# Altium schematic sheet pico2hat_halow.SchDoc(pico2hat_halow)
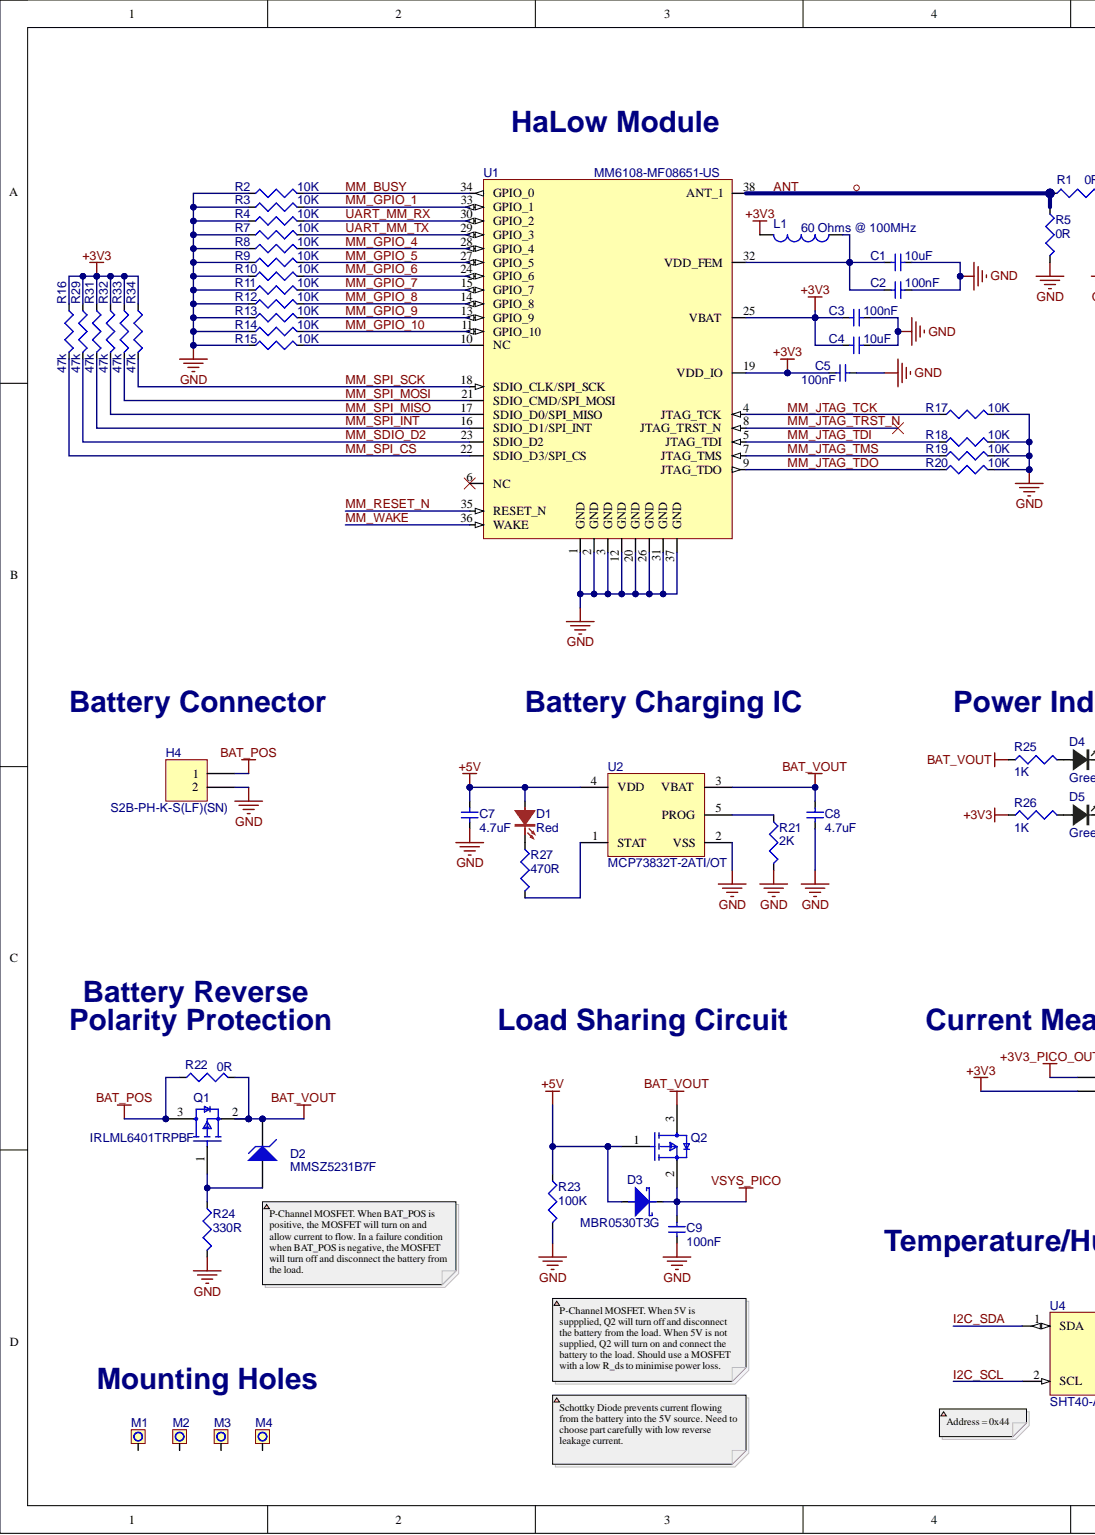
[diagram p0: pico2hat_halow.SchDoc, left]
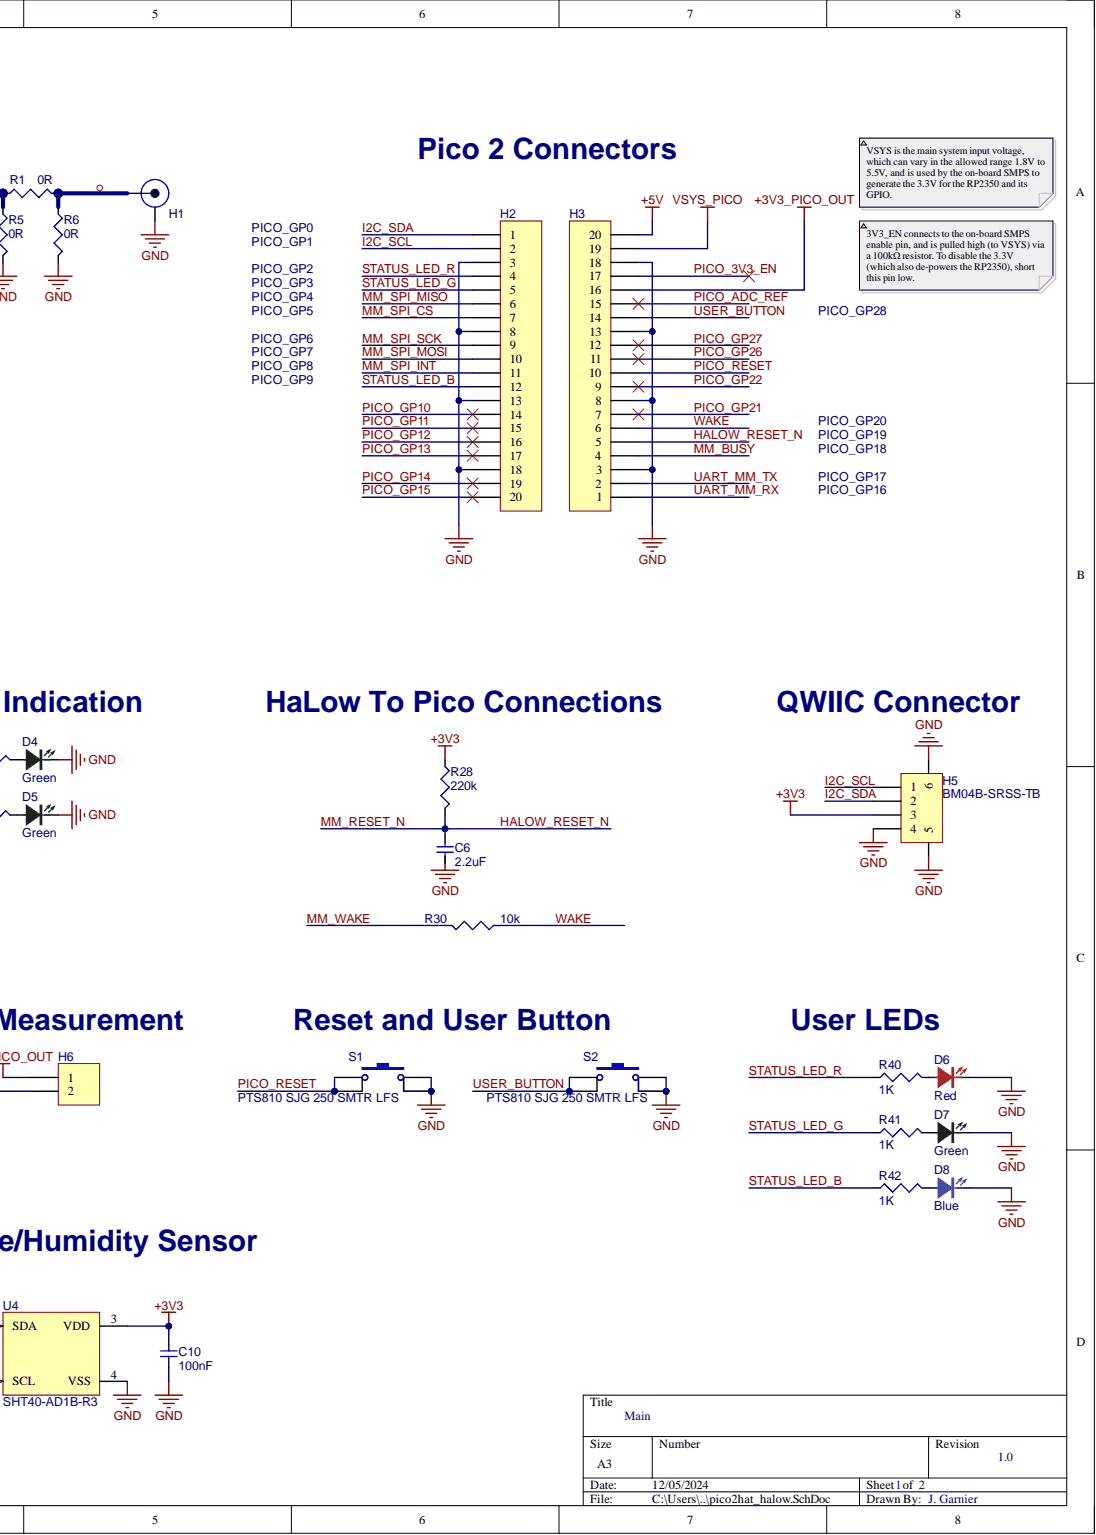
[diagram p1: pico2hat_halow.SchDoc, right]

## Parts
C1  value 10uF  [0402_cap]  (hidden comment: CL05A106MP8NUB8)
C2  value 100nF  [0402_cap]  (hidden comment: C1005X7R1H104K050BE)
C3  value 100nF  [0402_cap]  (hidden comment: C1005X7R1H104K050BE)
C4  value 10uF  [0402_cap]  (hidden comment: CL05A106MP8NUB8)
C5  value 100nF  [0402_cap]  (hidden comment: C1005X7R1H104K050BE)
C6  value 2.2uF  [CAPC1005X06N]  (hidden comment: CAP)
C7  value 4.7uF  [0402_cap]  (hidden comment: C1005X5R0J475K050BC)
C8  value 4.7uF  [0402_cap]  (hidden comment: C1005X5R0J475K050BC)
C9  value 100nF  [0402_cap]  (hidden comment: C1005X7R1H104K050BE)
C10  value 100nF  [0402_cap]  (hidden comment: C1005X7R1H104K050BE)
D1  value Red  [LED_0805_RED]  (hidden comment: LTST-C170KRKT)
D2  MMSZ5231B7F  [SOD-123FL]
D3  MBR0530T3G  [SOD-123FL]
D4  value Green  [LED_0402_GREEN]  (hidden comment: XZVGR68W-3)
D5  value Green  [LED_0402_GREEN]  (hidden comment: XZVGR68W-3)
D6  value Red  [LED_0805_RED]  (hidden comment: LTST-C170KRKT)
D7  value Green  [LED_0805_GREEN]  (hidden comment: LTST-C170KGKT)
D8  value Blue  [LED_0805_BLUE]  (hidden comment: LTST-C170TBKT)
H1  142-0761-861  [SMA_EMERSON_142_0761_861]  (value not drawn)
H2  1x20 Female Header  [HDR1X20F]  (value not drawn)
H3  1x20 Female Header  [HDR1X20F]  (value not drawn)
H4  S2B-PH-K-S(LF)(SN)  [JST-S2B-PH-K-S_V]
H5  BM04B-SRSS-TB  [FP-BM04B-SRSS-TBT_LF_SN-MFG]
H6  2011-1X02G00SB-1  [HDR1X2]  (value not drawn)
L1  value 60 Ohms @ 100MHz  [0402_ind]  (hidden comment: BLM15PX600SN1D)
M1  PAD0009  [MH_300_275_NPTH]  (value not drawn)
M2  PAD0009  [MH_300_275_NPTH]  (value not drawn)
M3  PAD0009  [MH_300_275_NPTH]  (value not drawn)
M4  PAD0009  [MH_300_275_NPTH]  (value not drawn)
Q1  IRLML6401TRPBF  [FP-SOT23-IPC_A]
Q2  NTS4101PT1G  [FP-419-04-IPC_A]  (value not drawn)
R1  value 0R  [0402_res]  (hidden comment: RC0402JR-070RL)
R2  value 10K  [RESC1005X04N]  (hidden comment: ERJ-2RKF1002X)
R3  value 10K  [RESC1005X04N]  (hidden comment: ERJ-2RKF1002X)
R4  value 10K  [RESC1005X04N]  (hidden comment: ERJ-2RKF1002X)
R5  value 0R  [0402_res]  (hidden comment: RC0402JR-070RL)
R6  value 0R  [0402_res]  (hidden comment: RC0402JR-070RL)
R7  value 10K  [RESC1005X04N]  (hidden comment: ERJ-2RKF1002X)
R8  value 10K  [RESC1005X04N]  (hidden comment: ERJ-2RKF1002X)
R9  value 10K  [RESC1005X04N]  (hidden comment: ERJ-2RKF1002X)
R10  value 10K  [RESC1005X04N]  (hidden comment: ERJ-2RKF1002X)
R11  value 10K  [RESC1005X04N]  (hidden comment: ERJ-2RKF1002X)
R12  value 10K  [RESC1005X04N]  (hidden comment: ERJ-2RKF1002X)
R13  value 10K  [RESC1005X04N]  (hidden comment: ERJ-2RKF1002X)
R14  value 10K  [RESC1005X04N]  (hidden comment: ERJ-2RKF1002X)
R15  value 10K  [RESC1005X04N]  (hidden comment: ERJ-2RKF1002X)
R16  value 47k  [RESC1005X04N]  (hidden comment: MCR01MZPF4702)
R17  value 10K  [RESC1005X04N]  (hidden comment: ERJ-2RKF1002X)
R18  value 10K  [RESC1005X04N]  (hidden comment: ERJ-2RKF1002X)
R19  value 10K  [RESC1005X04N]  (hidden comment: ERJ-2RKF1002X)
R20  value 10K  [RESC1005X04N]  (hidden comment: ERJ-2RKF1002X)
R21  value 2K  [0402_res]  (hidden comment: RC0402FR-072KL)
R22  value 0R  [RESC1608X06N]  (hidden comment: RC0603JR-070RL)
R23  value 100K  [0402_res]  (hidden comment: RC0402FR-07100KL)
R24  value 330R  [RESC1005X04N]  (hidden comment: RC0402FR-07330RL)
R25  value 1K  [RESC1005X04N]  (hidden comment: ERJ-2RKF1001X)
R26  value 1K  [RESC1005X04N]  (hidden comment: ERJ-2RKF1001X)
R27  value 470R  [0402_res]  (hidden comment: RC0402FR-07470RL)
R28  value 220k  [RESC1005X04N]  (hidden comment: RES)
R29  value 47k  [RESC1005X04N]  (hidden comment: MCR01MZPF4702)
R30  value 10k  [RESC1608X06N]  (hidden comment: RES)
R31  value 47k  [RESC1005X04N]  (hidden comment: MCR01MZPF4702)
R32  value 47k  [RESC1005X04N]  (hidden comment: MCR01MZPF4702)
R33  value 47k  [RESC1005X04N]  (hidden comment: MCR01MZPF4702)
R34  value 47k  [RESC1005X04N]  (hidden comment: MCR01MZPF4702)
R40  value 1K  [RESC1005X04N]  (hidden comment: ERJ-2RKF1001X)
R41  value 1K  [RESC1005X04N]  (hidden comment: ERJ-2RKF1001X)
R42  value 1K  [RESC1005X04N]  (hidden comment: ERJ-2RKF1001X)
S1  PTS810 SJG 250 SMTR LFS  [SW_PTS810]
S2  PTS810 SJG 250 SMTR LFS  [SW_PTS810]
U1  MM6108-MF08651-US  [MF04]
U2  MCP73832T-2ATI/OT  [SOT-23-OT5_N]
U4  SHT40-AD1B-R3  [DFN140P150X59-4N]

## Nets
+3V3: C3.1 C4.1 C5.1 C10.2 H5.3 H6.2 L1.2 R16.1 R26.1 R28.1 R29.1 R31.1 R32.1 R33.1 R34.1 U1.19(VDD_IO) U1.25(VBAT) U4.3(VDD)
+3V3_PICO_OUT: H3.16 H6.1
+5V: C7.1 D1.A D3.A H3.20 Q2.1 R23.1 U2.4(VDD)
BAT_POS: H4.1 Q1.3 R22.1
BAT_VOUT: C8.1 D2.K Q1.2 Q2.3 R22.2 R25.1 U2.3(VBAT)
GND: C1.2 C2.2 C3.2 C4.2 C5.2 C6.2 C7.2 C8.2 C9.1 C10.1 D4.K D5.K D6.K D7.K D8.K H1.2 H2.3 H2.8 H2.13 H2.18 H3.3 H3.8 H3.13 H3.18 H4.2 H5.4 H5.5 H5.6 R2.1 R3.1 R4.1 R5.2 R6.2 R7.1 R8.1 R9.1 R10.1 R11.1 R12.1 R13.1 R14.1 R15.1 R17.2 R18.2 R19.2 R20.2 R21.2 R23.2 R24.2 S1.3 S1.4 S2.3 S2.4 U1.1(GND) U1.2(GND) U1.3(GND) U1.12(GND) U1.20(GND) U1.26(GND) U1.31(GND) U1.37(GND) U2.2(VSS) U4.4(VSS)
VSYS_PICO: C9.2 D3.K H3.19 Q2.2
ANT: R1.1 R5.1 U1.38(ANT_1)
HALOW_RESET_N: C6.1 H3.5 R28.2 U1.35(RESET_N)
I2C_SCL: H2.2 H5.1 U4.2(SCL)
I2C_SDA: H2.1 H5.2 U4.1(SDA)
MM_BUSY: H3.4 R2.2 U1.34(GPIO_0)
MM_GPIO_1: R3.2 U1.33(GPIO_1)
MM_GPIO_4: R8.2 U1.28(GPIO_4)
MM_GPIO_5: R9.2 U1.27(GPIO_5)
MM_GPIO_6: R10.2 U1.24(GPIO_6)
MM_GPIO_7: R11.2 U1.15(GPIO_7)
MM_GPIO_8: R12.2 U1.14(GPIO_8)
MM_GPIO_9: R13.2 U1.13(GPIO_9)
MM_GPIO_10: R14.2 U1.11(GPIO_10)
MM_JTAG_TCK: R17.1 U1.4(JTAG_TCK)
MM_JTAG_TDI: R18.1 U1.5(JTAG_TDI)
MM_JTAG_TDO: R20.1 U1.9(JTAG_TDO)
MM_JTAG_TMS: R19.1 U1.7(JTAG_TMS)
MM_JTAG_TRST_N: U1.8(JTAG_TRST_N)
MM_SDIO_D2: R29.2 U1.23(SDIO_D2)
MM_SPI_CS: H2.7 R16.2 U1.22(SDIO_D3/SPI_CS)
MM_SPI_INT: H2.11 R31.2 U1.16(SDIO_D1/SPI_INT)
MM_SPI_MISO: H2.6 R32.2 U1.17(SDIO_D0/SPI_MISO)
MM_SPI_MOSI: H2.10 R33.2 U1.21(SDIO_CMD/SPI_MOSI)
MM_SPI_SCK: H2.9 R34.2 U1.18(SDIO_CLK/SPI_SCK)
MM_WAKE: R30.1 U1.36(WAKE)
PICO_3V3_EN: H3.17
PICO_ADC_REF: H3.15
PICO_GP10: H2.14
PICO_GP11: H2.15
PICO_GP12: H2.16
PICO_GP13: H2.17
PICO_GP14: H2.19
PICO_GP15: H2.20
PICO_GP21: H3.7
PICO_GP22: H3.9
PICO_GP26: H3.11
PICO_GP27: H3.12
PICO_RESET: H3.10 S1.1 S1.2
STATUS_LED_B: H2.12 R42.1
STATUS_LED_G: H2.5 R41.1
STATUS_LED_R: H2.4 R40.1
UART_MM_RX: H3.1 R4.2 U1.30(GPIO_2)
UART_MM_TX: H3.2 R7.2 U1.29(GPIO_3)
USER_BUTTON: H3.14 S2.1 S2.2
WAKE: H3.6 R30.2
NetC1_1: C1.1 C2.1 L1.1 U1.32(VDD_FEM)
NetD1_K: D1.K R27.1
NetD2_A: D2.A Q1.1 R24.1
NetD4_A: D4.A R25.2
NetD5_A: D5.A R26.2
NetD6_A: D6.A R40.2
NetD7_A: D7.A R41.2
NetD8_A: D8.A R42.2
NetH1_1: H1.1 R1.2 R6.1
NetR15_2: R15.2 U1.10(NC)
NetR21_1: R21.1 U2.5(PROG)
NetR27_2: R27.2 U2.1(STAT)

Not connected: M1 1; M2 1; M3 1; M4 1; U1 6(NC)

## Library data of the parts
C1 C4 = CAP0046-13: CAP CER 10UF 10V 20% X5R 0402; Generic Allowed: Yes; Subtype: Ceramic; ClassName: CHIP_MICRO; Component Kind: Standard; Package: 0402; Tolerance: 20%; Voltage: 10V; Library Name: Morse Micro Pty Limited
C2 C3 C5 C9 C10 = CAP0068-11: CAP CER 100NF 50V ±10% X7R 0402; Generic Allowed: Yes; Subtype: Ceramic; ClassName: CHIP_MICRO; Component Kind: Standard; Component Rating: X7R; Package: 0402; Tolerance: 10%; Voltage: 50V; Library Name: Morse Micro Pty Limited
C6 = CAP0086: CAP CER 2.2UF 6.3V 20% X5R 0402; Manufacturer 1: Taiyo Yuden; Manufacturer Part Number 1: JMK105BJ225MV-F; Database Table Name: Capacitor; Generic Allowed: Yes; Subtype: Ceramic; ClassName: CHIP_MICRO; Component Kind: Standard; Component Rating: X5R; Item_Number: CAP0086; Library Name: Altium_Library.DbLib; Package: 0402; Supplier 1: Digi-Key; Supplier Part Number 1: 587-1453-2-ND; Tolerance: 20%; Voltage: 6.3V
C7 C8 = CAP0075-12: CAP CER 4.7UF 6.3V ±10% X5R 0402; Generic Allowed: Yes; Subtype: Ceramic; ClassName: CHIP_MICRO; Component Kind: Standard; Component Rating: X5R; Package: 0402; Tolerance: 10%; Voltage: 6.3V; Library Name: Morse Micro Pty Limited
D1 D6 = DIO0016-2: LED, Red Clear,2.0V, SMD, 0805, RoHS; Generic Allowed: Yes; ClassName: CHIP_OTHER; Component Kind: Standard; Component Rating: Clear, 2.0V; Package: SMD-0805; Library Name: Morse Micro Pty Limited
D2 = DIO0072-1: DIODE ZENER 5.1V 500MW SOD-123; Component Kind: Standard; Library Name: Morse Micro Pty Limited
D3 = DIO0044-2: DIODE SCHOTTKY 30V 500MA SOD123; Generic Allowed: Yes; ClassName: COMP_OTHER; Component Kind: Standard; Current: 500mA; Package: SOD-123; Value: MBR0530T3G; Voltage: 30V; Library Name: Morse Micro Pty Limited
D4 D5 = DIO0053-3: LED GREEN 0402 SMD; Generic Allowed: Yes; Voltage: 2.0V; ClassName: CHIP_OTHER; Component Kind: Standard; Package: 0402; Library Name: Morse Micro Pty Limited
D7 = DIO0015-2: LED, Green Clear,2.0V, SMD, 0805, RoHS; Generic Allowed: Yes; ClassName: CHIP_OTHER; Component Kind: Standard; Component Rating: Clear, 2.0V; Package: SMD-0805; Library Name: Morse Micro Pty Limited
D8 = DIO0018-2: LED, Blue Clear,3.3V, SMD, 0805, RoHS; Generic Allowed: Yes; ClassName: CHIP_OTHER; Component Kind: Standard; Component Rating: Clear, 3.3V; Package: SMD-0805; Library Name: Morse Micro Pty Limited
H1 = JCK0001-2: Conn, SMA Jack 50 Ohm PCB Edge Mount Gold, RoHS; Generic Allowed: No; ClassName: COMP_OTHER; Component Kind: Standard; Package: Edge Mnt; Library Name: Morse Micro Pty Limited
H2 H3 = HDR0128-1: Component Kind: Standard; Description: 1x20 Female Header; Library Name: Morse Micro Pty Limited
H4 = HDR0129-2: Header for PCB; pitch 2.00mm; 2-contacts; THT; male; side entry; 100V/2A; Component Kind: Standard; Library Name: Morse Micro Pty Limited
H5 = HDR0131-2: Board Connector, 4 Contact(s), 1 Row(s), Male, Straight, Surface Mount Terminal; Component Kind: Standard; Library Name: Morse Micro Pty Limited
H6 = HDR0006-2: Conn Straight Header 2x1 0.100" 2 POS; Generic Allowed: Yes; ClassName: COMP_OTHER; Component Kind: Standard; Package: THRU-Hole; Pins: 2; Library Name: Morse Micro Pty Limited
L1 = IND0062-6: BEAD 60OHMS 2500MA 32 MOHM; Current: 2.5A; DC Resistance: 32mOhm; Generic Allowed: Yes; Value: 60 Ohms @ 100MHz; ClassName: CHIP_MICRO; Component Kind: Standard; Package: 0402; Resistance: 32mOhm; Library Name: Morse Micro Pty Limited
M1-M4 = PAD0009-2: MH NPTH 3.00mm DIA 2.75mm HOLE; Shape: Round; ClassName: PCB_PATTERN; Component Kind: Standard; Library Name: Morse Micro Pty Limited
Q1 = TSR0020-1: Small Signal Field-Effect Transistor; Additional Feature: HIGH RELIABILITY; Avalanche Energy Rating (Eas): 33mJ; Drain Current-Max (Abs) (ID): 4.3A; Drain-source On Resistance-Max: 50mR; DS Breakdown Voltage-Min: 12V; FET Technology: METAL-OXIDE SEMICONDUCTOR; Number of Elements: 1; Operating Mode: ENHANCEMENT MODE; Package Body Material: Plastic; Polarity/Channel Type: P-CHANNEL; Power Dissipation-Max (Abs): 1.3W; Pulsed Drain Current-Max (IDM): 34A; Qualification Status: Not Qualified; Time @ Peak Reflow Temperature-Max (s): 30s; Transistor Application: SWITCHING; Transistor Element Material: SILICON; Component Kind: Standard; Drain Current-Max (ID): 4.3A; JESD-30 Code: R-PDSO-G3; JESD-609 Code: e3; Max Operating Temperature: 150°C; Moisture Sensitivity Level: 1; Package Shape: Rectangular; Package Style: SMALL OUTLINEMeter; Peak Reflow Temperature (Cel): 260°C; Pins: 3; Reach SVHC Compliant: Yes; RoHS Compliant: Yes; Surface Mount: Yes; Terminal Finish: Tin; Terminal Form: Gull Wing; Terminal Position: DUAL; Library Name: Morse Micro Pty Limited
Q2 = TSR0021-1: Small Signal Field-Effect Transistor, 1.37A I(D), 20V, 1-Element, P-Channel, Silicon, Metal-oxide Semiconductor FET; Drain-source On Resistance-Max: 120mR; Feedback Cap-Max (Crss): 85pF; Number of Elements: 1; Operating Mode: ENHANCEMENT MODE; Package Body Material: Plastic; Power Dissipation-Max (Abs): 329mW; Qualification Status: Not Qualified; Time @ Peak Reflow Temperature-Max (s): 40s; Transistor Application: SWITCHING; Component Kind: Standard; Drain Current-Max (Abs) (ID): 1.37A; Drain Current-Max (ID): 1.37A; DS Breakdown Voltage-Min: 20V; FET Technology: METAL-OXIDE SEMICONDUCTOR; JESD-30 Code: R-PDSO-G3; JESD-609 Code: e3; Max Operating Temperature: 150°C; Moisture Sensitivity Level: 1; Package Shape: Rectangular; Package Style: SMALL OUTLINEMeter; Peak Reflow Temperature (Cel): 260°C; Pins: 3; Polarity/Channel Type: P-CHANNEL; Reach SVHC Compliant: Yes; RoHS Compliant: Yes; Surface Mount: Yes; Terminal Finish: Tin; Terminal Form: Gull Wing; Terminal Position: DUAL; Transistor Element Material: SILICON; Library Name: Morse Micro Pty Limited
R1 R5 R6 = RES0039-10: RES SMD 0R OHM ±1% 1/16W 0402; Generic Allowed: Yes; Subtype: Thin Film; ClassName: CHIP_MICRO; Component Kind: Standard; Package: 0402; Power: 1/16W; Tolerance: 1%; Library Name: Morse Micro Pty Limited
R2-R4 R7-R15 R17-R20 = RES0002-8: RES SMD 10K OHM ±1% 1/10W 0402; Generic Allowed: Yes; ClassName: CHIP_MICRO; Component Kind: Standard; Package: 0402; Power: 1/10W; Tolerance: 1%; Library Name: Morse Micro Pty Limited
R16 R29 R31-R34 = RES0086-8: RES SMD 47K OHM ±1% 1/16W 0402; Generic Allowed: Yes; ClassName: CHIP_MICRO; Component Kind: Standard; Package: 0402; Power: 1/16W; Tolerance: 1%; Library Name: Morse Micro Pty Limited
R21 = RES0030-9: RES SMD 2K OHM ±1% 1/16W 0402; Generic Allowed: Yes; ClassName: CHIP_MICRO; Component Kind: Standard; Package: 0402; Power: 1/16W; Tolerance: 1%; Library Name: Morse Micro Pty Limited
R22 = RES0119-6: RES SMD 0R OHM ±5% 1/10W 0603; Generic Allowed: Yes; ClassName: CHIP_OTHER; Component Kind: Standard; Package: 0603; Power: 1/10W; Tolerance: 5%; Library Name: Morse Micro Pty Limited
R23 = RES0023-9: RES SMD 100K OHM ±1% 1/16W 0402; Generic Allowed: Yes; ClassName: CHIP_MICRO; Component Kind: Standard; Package: 0402; Power: 1/16W; Tolerance: 1%; Library Name: Morse Micro Pty Limited
R24 = RES0025-8: RES SMD 330R OHM ±1% 1/16W 0402; Generic Allowed: Yes; ClassName: CHIP_MICRO; Component Kind: Standard; Package: 0402; Power: 1/16W; Tolerance: 1%; Library Name: Morse Micro Pty Limited
R25 R26 R40-R42 = RES0004-8: RES SMD 1K OHM ±1% 1/10W 0402; Generic Allowed: Yes; ClassName: CHIP_MICRO; Component Kind: Standard; Package: 0402; Power: 1/10W; Tolerance: 1%; Library Name: Morse Micro Pty Limited
R27 = RES0084-9: RES SMD 470R OHM ±1% 1/16W 0402; Generic Allowed: Yes; ClassName: CHIP_MICRO; Component Kind: Standard; Package: 0402; Power: 1/16W; Tolerance: 1%; Library Name: Morse Micro Pty Limited
R28 = RES0064: Res, 220k Ohms, 1/16W, 1%, 0402,SMD; Manufacturer 1: Rohm; Manufacturer Part Number 1: MCR01MZPF2203; Database Table Name: Resistor; Generic Allowed: Yes; ClassName: CHIP_MICRO; Component Kind: Standard; Item_Number: RES0064; Library Name: Altium_Library.DbLib; Manufacturer 2: Yageo; Manufacturer Part Number 2: RC0402FR-07220KL; Package: 0402; Power: 1/16W; Supplier 1: Digi-Key; Supplier 2: Digi-Key; Supplier Part Number 1: 311-220KLRCT-ND; Supplier Part Number 2: 311-220KLRTR-ND; Tolerance: 1%
R30 = RES0124: Res, 10K Ohm, 1/10W,+/-1%,0603 RoHS; Manufacturer 1: Rohm; Manufacturer Part Number 1: MCR03EZPFX1002; Database Table Name: Resistor; Generic Allowed: Yes; Tolerance: 1%; ClassName: CHIP_OTHER; Component Kind: Standard; Item_Number: RES0124; Library Name: Altium_Library.DbLib; Manufacturer 2: Yageo; Manufacturer Part Number 2: RC0603FR-0710KL; Package: 0603; Power: 1/10W; Supplier 1: Digi-Key; Supplier 2: Digi-Key; Supplier Part Number 1: 311-10.0KHRCT-ND; Supplier Part Number 2: 311-10.0KHRTR-ND
S1 S2 = SWH0026-1: SWITCH TACTILE SPST-NO 0.05A 16V; Generic Allowed: No; ClassName: COMP_OTHER; Component Kind: Standard; Package: SMD; Pins: 4; Value: PTS810 SJG 250 SMTR LFS; Library Name: Morse Micro Pty Limited
U1 = MOD0010-3: MM61xx-MF08; Item_Number: MOD0061; Value: MM61xx-MF08251; ClassName: COM_OTHER; Component Kind: Standard; Library Name: Morse Micro Pty Limited
U2 = ICT0232-1: Power Supply Support Circuit, Adjustable, 1 Channel, PDSO5; Adjustable Threshold: Yes; Channels: 1; Max Supply Voltage: 6V; Min Supply Voltage: 3.75V; Package Body Material: Plastic; Package Equivalence Code: TSOP5/6,.11,37; Power Supplies: 3.3/5V; Qualification Status: Not Qualified; Supply Current-Max (Isup): 1.5mA; Time @ Peak Reflow Temperature-Max (s): 40s; Case/Package: LSSOP; Component Kind: Standard; Height - Seated (Max): 1.45mm; JESD-30 Code: R-PDSO-G5; JESD-609 Code: e3; Length: 2.95mm; Max Operating Temperature: 85°C; Min Operating Temperature: -40°C; Moisture Sensitivity Level: 1; Number of Channels: 1; Package Shape: Rectangular; Package Style: SMALL OUTLINE, LOW PROFILE, SHRINK PITCHMeter; Peak Reflow Temperature (Cel): 260°C; Pins: 5; Reach SVHC Compliant: Yes; RoHS Compliant: Yes; Surface Mount: Yes; Temperature Grade: INDUSTRIAL; Terminal Finish: Tin; Terminal Form: Gull Wing; Terminal Pitch: 0.95mm; Terminal Position: DUAL; Throw Configuration: POWER SUPPLY SUPPORT CIRCUIT; Width: 1.65mm; Library Name: Morse Micro Pty Limited
U4 = ICT0108-3: IC, Humidity Temperature Sensor 0 ~ 100% RH I²C ±1.8% RH 6 s Surface Mount; Generic Allowed: No; ClassName: CHIP_OTHER; Component Kind: Standard; Package: DFN-4; Value: SHT40-AD1B-R3; Library Name: Morse Micro Pty Limited
